# Altium schematic sheet Chip.SchDoc(Chip)
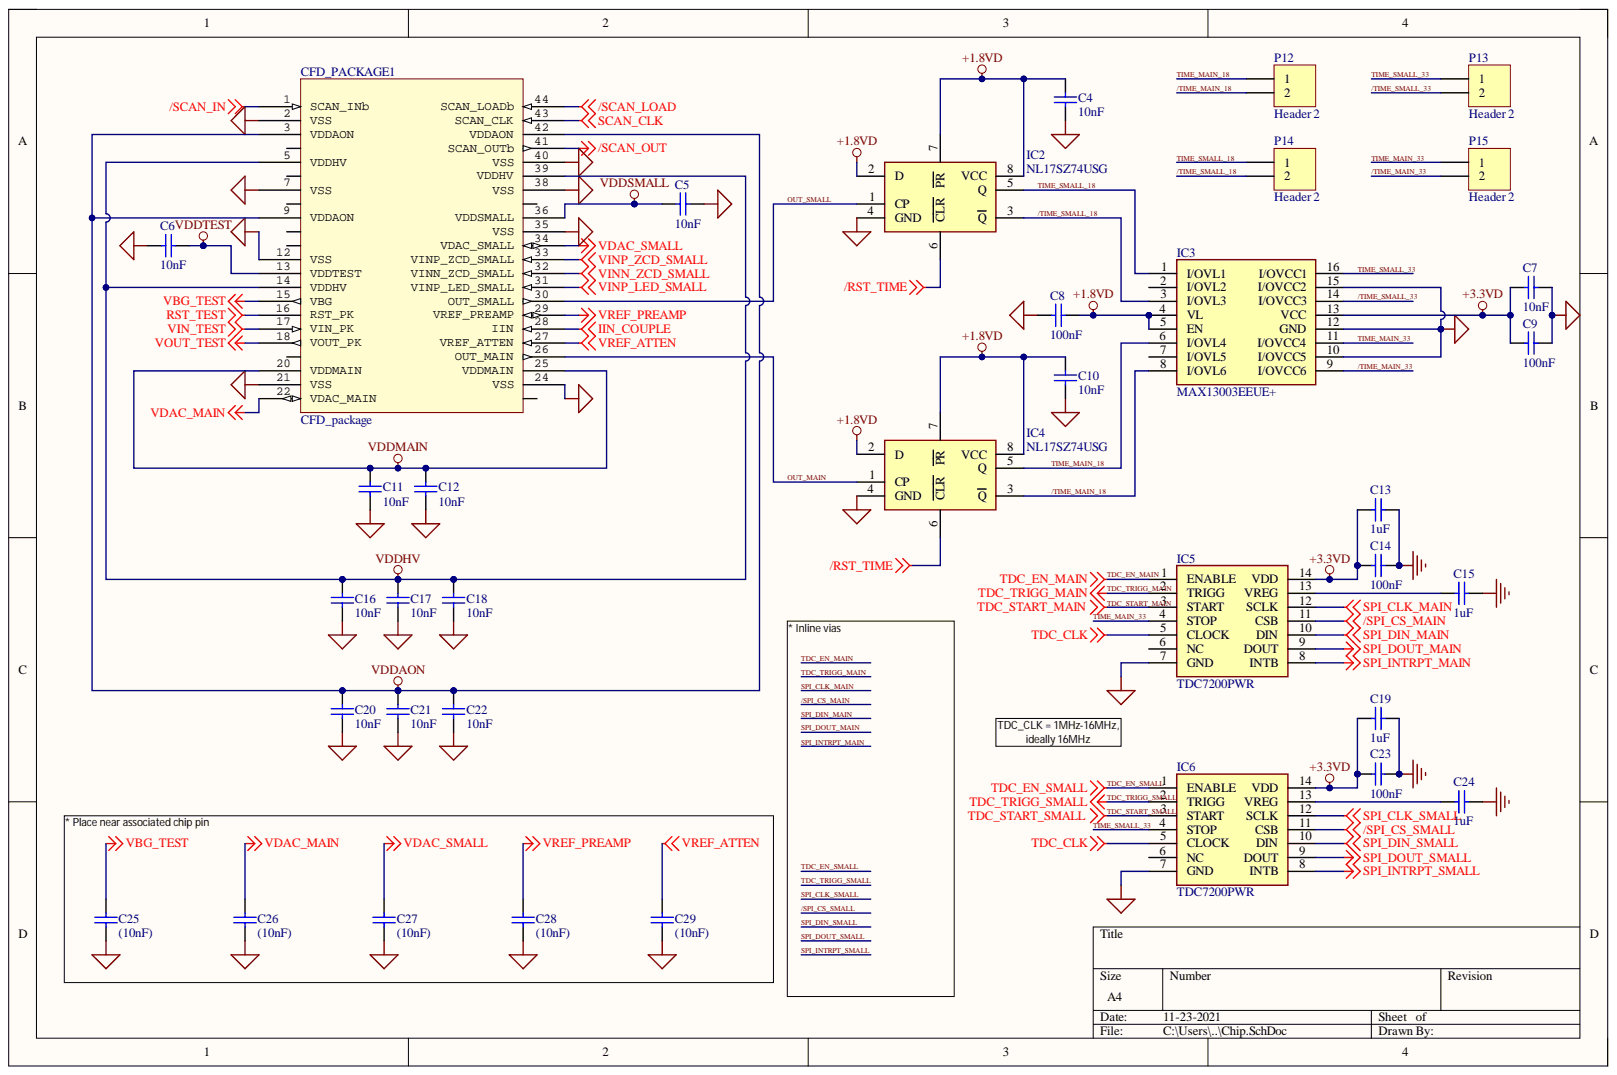
[diagram p0: Chip.SchDoc]

## Parts
C4  10nF  [CAPC1608X90X35ML10T15]
C5  10nF  [CAPC1608X90X35ML10T15]
C6  10nF  [CAPC1608X90X35ML10T15]
C7  10nF  [CAPC1608X90X35ML10T15]
C8  100nF  [CAPC1608X90X35ML10T15]
C9  100nF  [CAPC1608X90X35ML10T15]
C10  10nF  [CAPC1608X90X35ML10T15]
C11  10nF  [CAPC1608X90X35ML10T15]
C12  10nF  [CAPC1608X90X35ML10T15]
C13  1uF  [CAPC1608X90X35ML10T15]
C14  100nF  [CAPC1608X90X35ML10T15]
C15  1uF  [CAPC1608X90X35ML10T15]
C16  10nF  [CAPC1608X90X35ML10T15]
C17  10nF  [CAPC1608X90X35ML10T15]
C18  10nF  [CAPC1608X90X35ML10T15]
C19  1uF  [CAPC1608X90X35ML10T15]
C20  10nF  [CAPC1608X90X35ML10T15]
C21  10nF  [CAPC1608X90X35ML10T15]
C22  10nF  [CAPC1608X90X35ML10T15]
C23  100nF  [CAPC1608X90X35ML10T15]
C24  1uF  [CAPC1608X90X35ML10T15]
C25  (10nF)  [CAPC1608X90X35ML10T15]
C26  (10nF)  [CAPC1608X90X35ML10T15]
C27  (10nF)  [CAPC1608X90X35ML10T15]
C28  (10nF)  [CAPC1608X90X35ML10T15]
C29  (10nF)  [CAPC1608X90X35ML10T15]
CFD_PACKAGE1  CFD_package  [QFN50P700X700X80_HS-45N_WIDEVIAS]
IC2  NL17SZ74USG  [SOP50P310X90-8N]
IC3  MAX13003EEUE+  [SOP65P640X110-16N]
IC4  NL17SZ74USG  [SOP50P310X90-8N]
IC5  TDC7200PWR  [SOP65P640X120-14N]
IC6  TDC7200PWR  [SOP65P640X120-14N]
P12  Header 2  [HDR1X2]
P13  Header 2  [HDR1X2]
P14  Header 2  [HDR1X2]
P15  Header 2  [HDR1X2]

## Nets
+1.8VD: C4.2 C8.2 C10.2 IC2.2(D) IC2.7(PR) IC2.8(VCC) IC3.4(VL) IC3.5(EN) IC4.2(D) IC4.7(PR) IC4.8(VCC)
+3.3VD: C7.2 C9.2 C13.1 C14.1 C19.1 C23.1 IC3.13(VCC) IC5.14(VDD) IC6.14(VDD)
/RST_TIME: IC2.6(CLR) IC4.6(CLR)
/SCAN_IN: CFD_PACKAGE1.1(SCAN_INb)
/SCAN_LOAD: CFD_PACKAGE1.44(SCAN_LOADb)
/SCAN_OUT: CFD_PACKAGE1.41(SCAN_OUTb)
GND: C4.1 C5.1 C6.2 C7.1 C8.1 C9.1 C10.1 C11.1 C12.1 C13.2 C14.2 C15.2 C16.1 C17.1 C18.1 C19.2 C20.1 C21.1 C22.1 C23.2 C24.2 C25.1 C26.1 C27.1 C28.1 C29.1 CFD_PACKAGE1.2(VSS) CFD_PACKAGE1.7(VSS) CFD_PACKAGE1.12(VSS) CFD_PACKAGE1.21(VSS) CFD_PACKAGE1.24(VSS) CFD_PACKAGE1.35(VSS) CFD_PACKAGE1.38(VSS) CFD_PACKAGE1.40(VSS) IC2.4(GND) IC3.10(I/OVCC5) IC3.12(GND) IC3.15(I/OVCC2) IC4.4(GND) IC5.7(GND) IC6.7(GND)
IIN_COUPLE: CFD_PACKAGE1.28(IIN)
RST_TEST: CFD_PACKAGE1.16(RST_PK)
SCAN_CLK: CFD_PACKAGE1.43(SCAN_CLK)
TDC_CLK: IC5.5(CLOCK) IC6.5(CLOCK)
VBG_TEST: C25.2 CFD_PACKAGE1.15(VBG)
VDAC_MAIN: C26.2 CFD_PACKAGE1.22(VDAC_MAIN)
VDAC_SMALL: C27.2 CFD_PACKAGE1.34(VDAC_SMALL)
VDDAON: C20.2 C21.2 C22.2 CFD_PACKAGE1.3(VDDAON) CFD_PACKAGE1.9(VDDAON) CFD_PACKAGE1.42(VDDAON)
VDDHV: C16.2 C17.2 C18.2 CFD_PACKAGE1.5(VDDHV) CFD_PACKAGE1.14(VDDHV) CFD_PACKAGE1.39(VDDHV)
VDDMAIN: C11.2 C12.2 CFD_PACKAGE1.20(VDDMAIN) CFD_PACKAGE1.25(VDDMAIN)
VDDSMALL: C5.2 CFD_PACKAGE1.36(VDDSMALL)
VDDTEST: C6.1 CFD_PACKAGE1.13(VDDTEST)
VIN_TEST: CFD_PACKAGE1.17(VIN_PK)
VINN_ZCD_SMALL: CFD_PACKAGE1.32(VINN_ZCD_SMALL)
VINP_LED_SMALL: CFD_PACKAGE1.31(VINP_LED_SMALL)
VINP_ZCD_SMALL: CFD_PACKAGE1.33(VINP_ZCD_SMALL)
VOUT_TEST: CFD_PACKAGE1.18(VOUT_PK)
VREF_ATTEN: C29.2 CFD_PACKAGE1.27(VREF_ATTEN)
VREF_PREAMP: C28.2 CFD_PACKAGE1.29(VREF_PREAMP)
/SPI_CS_MAIN: IC5.11(CSB)
/SPI_CS_SMALL: IC6.11(CSB)
/TIME_MAIN_18: IC3.8(I/OVL6) IC4.3(Q) P12.2
/TIME_MAIN_33: IC3.9(I/OVCC6) P15.2
/TIME_SMALL_18: IC2.3(Q) IC3.3(I/OVL3) P14.2
/TIME_SMALL_33: IC3.14(I/OVCC3) P13.2
OUT_MAIN: CFD_PACKAGE1.26(OUT_MAIN) IC4.1(CP)
OUT_SMALL: CFD_PACKAGE1.30(OUT_SMALL) IC2.1(CP)
SPI_CLK_MAIN: IC5.12(SCLK)
SPI_CLK_SMALL: IC6.12(SCLK)
SPI_DIN_MAIN: IC5.10(DIN)
SPI_DIN_SMALL: IC6.10(DIN)
SPI_DOUT_MAIN: IC5.9(DOUT)
SPI_DOUT_SMALL: IC6.9(DOUT)
SPI_INTRPT_MAIN: IC5.8(INTB)
SPI_INTRPT_SMALL: IC6.8(INTB)
TDC_EN_MAIN: IC5.1(ENABLE)
TDC_EN_SMALL: IC6.1(ENABLE)
TDC_START_MAIN: IC5.3(START)
TDC_START_SMALL: IC6.3(START)
TDC_TRIGG_MAIN: IC5.2(TRIGG)
TDC_TRIGG_SMALL: IC6.2(TRIGG)
TIME_MAIN_18: IC3.6(I/OVL4) IC4.5(Q) P12.1
TIME_MAIN_33: IC3.11(I/OVCC4) IC5.4(STOP) P15.1
TIME_SMALL_18: IC2.5(Q) IC3.1(I/OVL1) P14.1
TIME_SMALL_33: IC3.16(I/OVCC1) IC6.4(STOP) P13.1
NetC15_1: C15.1 IC5.13(VREG)
NetC24_1: C24.1 IC6.13(VREG)

Not connected: CFD_PACKAGE1 4 6 8 10 11 19 23 37; IC3 2(I/OVL2) 7(I/OVL5); IC5 6(NC); IC6 6(NC)

## Library data of the parts
C4-C29 = CMP-2000-04945-1: 0603; Manufacturer: Murata; Capacitance: 22pF; Dielectric: C0G; Rated Voltage: 50V; Tolerance (%): ±5%; Case Size/Dimension: 0603; Component Kind: Standard; Datasheet URL: https://datasheet.ciiva.com/11786/0900766b807a1cab-11786398.pdf; Datasheet Version: Jul-06; Library Name: Altium Content Vault; Manufacturer URL: http://www.murata.com/; Package Description: 2-Pin Surface Mount Device, Body 1.6 x 0.8 mm; Package Reference: 603
IC2 IC4 = NL17SZ74USG: Flip Flops 1.65-5.5V CMOS Single D-Type; Manufacturer_Name: ON Semiconductor; Component Kind: Standard; Datasheet Link: http://www.onsemi.com/pub/Collateral/NL17SZ74-D.PDF; Height: 0.9mm; Library Name: SamacSys.SchLib; Manufacturer_Part_Number: NL17SZ74USG
IC3 = MAX13003EEUE+: Integrated Circuit; Manufacturer_Name: Maxim Integrated; Component Kind: Standard; Datasheet Link: https://pdfserv.maximintegrated.com/en/ds/MAX13000E-MAX13005E.pdf; Height: 1.1mm; Manufacturer_Part_Number: MAX13003EEUE+
IC5 IC6 = TDC7200PWR: Integrated Circuit; Manufacturer_Name: Texas Instruments; Component Kind: Standard; Datasheet Link: http://www.ti.com/lit/gpn/tdc7200; Height: 1.2mm; Manufacturer_Part_Number: TDC7200PWR
P12-P15 = Header 2: Header, 2-Pin; Component Kind: Standard; LatestRevisionDate: 17-Jul-2002; LatestRevisionNote: Re-released for DXP Platform.; Library Name: Miscellaneous Connectors.IntLib; Signal Integrity: Connector; Publisher: Altium Limited
CFD_PACKAGE1 = CFD_package: Component Kind: Standard; Library Name: intlib.IntLib
